# Altium schematic sheet Power.SchDoc(Power)
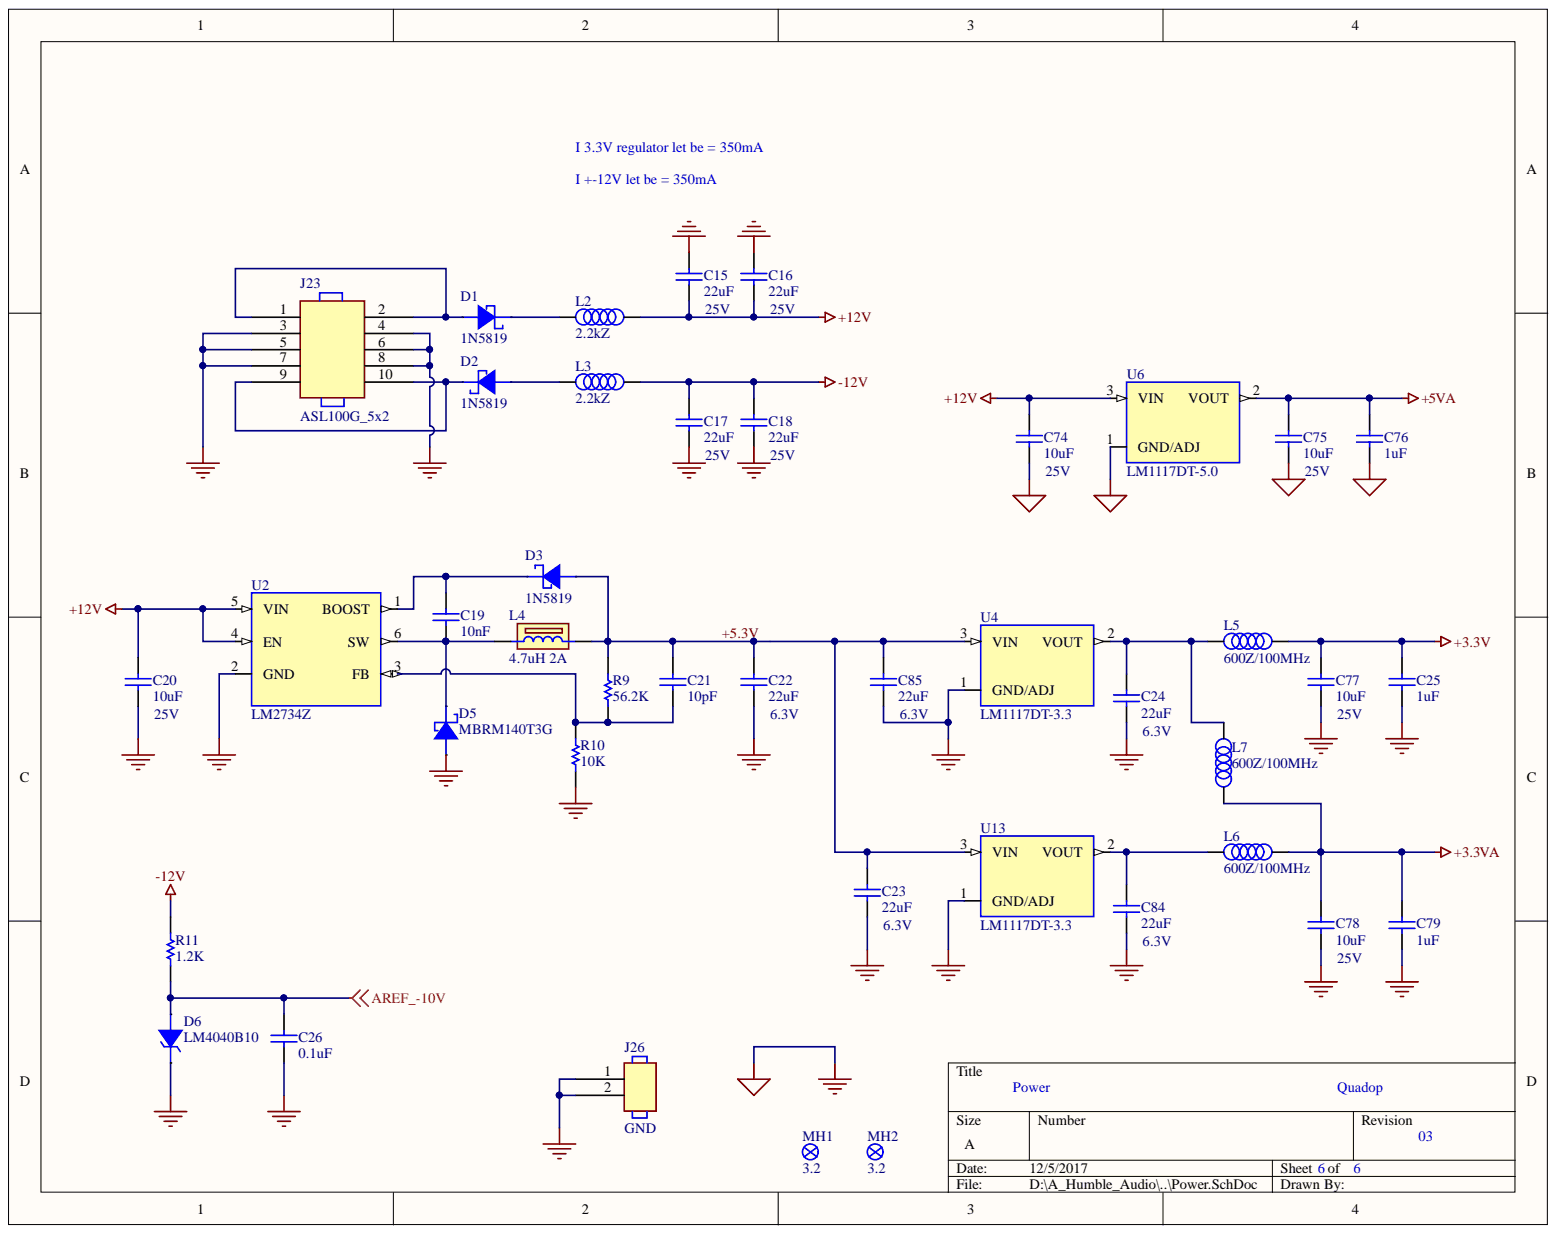
[diagram p0: Power.SchDoc]

## Parts
C15  22uF  Voltage: 25V  [C1210]
C16  22uF  Voltage: 25V  [C1210]
C17  22uF  Voltage: 25V  [C1210]
C18  22uF  Voltage: 25V  [C1210]
C19  10nF  [C0603]
C20  10uF  Voltage: 25V  [C0805]
C21  10pF  [C0603]
C22  22uF  Voltage: 6.3V  [C1206]
C23  22uF  Voltage: 6.3V  [C1206]
C24  22uF  Voltage: 6.3V  [C1206]
C25  1uF  [C0603]
C26  0.1uF  [C0603]
C74  10uF  Voltage: 25V  [C0805]
C75  10uF  Voltage: 25V  [C0805]
C76  1uF  [C0603]
C77  10uF  Voltage: 25V  [C0805]
C78  10uF  Voltage: 25V  [C0805]
C79  1uF  [C0603]
C84  22uF  Voltage: 6.3V  [C1206]
C85  22uF  Voltage: 6.3V  [C1206]
D1  1N5819  [SOD123]
D2  1N5819  [SOD123]
D3  1N5819  [SOD123]
D5  MBRM140T3G  [DO_216AA]
D6  LM4040B10  [SOT23]
J23  ASL100G_5x2  [SL2X5]
J26  GND  [SL1X2]
L2  2.2kZ  [M0805]
L3  2.2kZ  [M0805]
L4  4.7uH 2A  [L_IFSC_3.8x3.8x1.8]
L5  600Z/100MHz  [L1608]
L6  600Z/100MHz  [L1608]
L7  600Z/100MHz  [L1608]
MH1  3.2  [SK_32]
MH2  3.2  [SK_32]
R9  56.2K  [R0603]
R10  10K  [R0603]
R11  1.2K  [R0603]
U2  LM2734Z  [SOT23_6]
U4  LM1117DT-3.3  [TO252AA]
U6  LM1117DT-5.0  [TO252AA]
U13  LM1117DT-3.3  [TO252AA]

## Nets
+3.3V: C25.1 C77.1 L5.2
+3.3VA: C78.1 C79.1 L6.2 L7.1
+5VA: C75.1 C76.1 U6.2
+12V: C15.2 C16.2 C20.1 C74.1 L2.2 U2.4(EN) U2.5(VIN) U6.3
-12V: C17.1 C18.1 L3.2 R11.1
AGND: C15.1 C16.1 C17.2 C18.2 C20.2 C22.2 C23.2 C24.2 C25.2 C26.2 C74.2 C75.2 C76.2 C77.2 C78.2 C79.2 C84.2 C85.2 D5.1 D6.1 J23.3 J23.4 J23.5 J23.6 J23.7 J23.8 J26.1 J26.2 R10.2 U2.2(GND) U4.1 U6.1 U13.1
AREF_-10V: C26.1 D6.2 R11.2
+5.3V: C21.1 C22.1 C23.1 C85.1 D3.1 L4.2 R9.1 U4.3 U13.3
NetC19_1: C19.1 D3.2 U2.1(BOOST)
NetC19_2: C19.2 D5.2 L4.1 U2.6(SW)
NetC21_2: C21.2 R9.2 R10.1 U2.3(FB)
NetC24_1: C24.1 L5.1 L7.2 U4.2
NetC84_1: C84.1 L6.1 U13.2
NetD1_1: D1.1 J23.1 J23.2
NetD1_2: D1.2 L2.1
NetD2_1: D2.1 L3.1
NetD2_2: D2.2 J23.9 J23.10

## Library data of the parts
C15-C18 = 00226255: 22uF 25V 20% X5R tanδ=5 1210 -55+85; Manufacturer Part Number: TMK325BJ226MM-T; Manufacturer: Taiyo Yuden; Tolerance: +-20%; PSpice: C; Database Table Name: Capacitor; Value: 22uF; Tape Width: 8mm; SMD: Yes; Price: 0.86; Part Type: Ceramic; Part Number: 00226255; Package: 500T&R; Distributor Part Number: 587-2086-1-ND; Distributor: Digi-Key; Component Kind: Standard; Library Name: Gedo_DB.DbLib
C19 = 00000007: 10nF 50V 10% X7R 0603; Manufacturer Part Number: CC0603KRX7R9BB103; Manufacturer: Yageo; PSpice: C; Database Table Name: Capacitor; Voltage: 50V; Value: 10nF; Tolerance: 10%; SMD: Yes; Price: 0.015; Part Type: Ceramic; Part Number: 00000007; Distributor Part Number: 311-1085-1-ND; Distributor: Digi-Key; Component Kind: Standard; Availability: In Stock; Library Name: Gedo_DB.DbLib
C20 C74 C75 C77 C78 = 00106253: 10uF 25V 10% X5R 0805 -55+85; Manufacturer Part Number: TMK212BBJ106KG-T; Manufacturer: Taiyo Yuden; Tolerance: +-10%; PSpice: C; Database Table Name: Capacitor; Value: 10uF; Tape Width: 8mm; SMD: Yes; Price: 0.385; Part Type: Ceramic; Part Number: 00106253; Package: 3000T&R; Distributor Part Number: 587-2985-1-ND; Distributor: Digi-Key; Component Kind: Standard; Library Name: Gedo_DB.DbLib
C21 = 00000144: 10pF 50V 5% NP0 0603 -55+85; Tolerance: +-5%; PSpice: C; Database Table Name: Capacitor; Voltage: 50V; Value: 10pF; Tape Width: 8mm; SMD: Yes; Part Type: Ceramic; Part Number: 00000144; Component Kind: Standard; Availability: In Stock; Library Name: Gedo_DB.DbLib
C22-C24 C84 C85 = 00226634: 22uF 6.3V 20% X5R 1206 -55+85; Manufacturer Part Number: JMK316BJ226ML-T; Manufacturer: Taiyo Yuden; Tolerance: +-20%; PSpice: C; Database Table Name: Capacitor; Value: 22uF; Tape Width: 8mm; SMD: Yes; Price: 0.399; Part Type: Ceramic; Part Number: 00226634; Package: 2000T&R; Distributor Part Number: 587-1347-1-ND; Distributor: Digi-Key; Component Kind: Standard; Library Name: Gedo_DB.DbLib
C25 C76 C79 = 00000550: 1uF 16V 10% X5R 0603 -55+85; Manufacturer Part Number: GRM188R71C105KA12D; Manufacturer: MURATA; Tolerance: +-10%; PSpice: C; Database Table Name: Capacitor; Voltage: 16V; Value: 1uF; Tape Width: 8mm; SMD: Yes; Price: 0.13; Part Type: Ceramic; Part Number: 00000550; Package: 4000T&R; Distributor Part Number: 490-3900-1-ND; Distributor: Digi-Key; Component Kind: Standard; Availability: In Stock; Library Name: Gedo_DB.DbLib
C26 = 00000538: 100nF 50V +-10% X7R 0603 -55+125; Manufacturer Part Number: GRM188R71H104KA93J; Manufacturer: MURATA; PSpice: C; Lead time: Stock; Database Table Name: Capacitor; Voltage: 50V; Value: 0.1uF; Tolerance: +-10%; Tape Width: 8mm; SMD: Yes; Price: 0.0048; Part Type: Ceramic; Part Number: 00000538; Package: 10000T&R330mm; MOQ: 4000; Main distributor: Rutronik; Distributor: Rutronik; Component Kind: Standard; Availability: In Stock; ActivepartsID: http://search.murata.co.jp/Ceramy/GrsearchViewAction.do;jsessionid=C4D720578E4D2E51EC807AFF78C1B5C3; Library Name: Gedo_DB.DbLib
D1 D2 = 30000028: Diode Schotky Rectifier 1A 40V Vf=0.45V@1A,SOD-123,-65+125; Manufacturer Part Number: 1N5819HW-7-F; Manufacturer: Diodes Inc; PSpice: D; Database Table Name: Diodai; Value: 1N5819; SMD: Yes; Price: 0.371; Part Type: Schotky; Part Number: 30000028; Distributor Part Number: 1N5819HW-FDICT-ND; Distributor: Digi-Key; Component Kind: Standard; Library Name: Gedo_DB.DbLib
D3 = 30000028: Diode Schotky Rectifier 1A 40V Vf=0.45V@1A,SOD-123,-65+125; Manufacturer Part Number: 1N5819HW-7-F; Manufacturer: Diodes Inc; Database Table Name: Diodai; Value: 1N5819; SMD: Yes; Price: 0.371; Part Number: 30000028; Distributor Part Number: 1N5819HW-FDICT-ND; Distributor: Digi-Key; Component Kind: Standard; Library Name: Gedo_DB.DbLib
D5 = 30000026: Diode Schotky Rectifier 1A 40V Vf=0.55V@1A,DO-216AA,-55+125; Manufacturer: ON Semiconductor; Database Table Name: Diodai; Value: MBRM140T3G; SMD: Yes; Price: 0.371; Part Number: 30000026; Manufacturer Part Number: MBRM140T3G; Distributor Part Number: MBRM140T3GOSCT-ND; Distributor: Digi-Key; Component Kind: Standard; Library Name: Gedo_DB.DbLib
D6 = 31404010: Diode Zener 10Vnom,15mA SOT23 ,-40+85; Manufacturer Part Number: LM4040B10IDBZR; Manufacturer: Texas Instruments; Tolerance: +-0.2%; PSpice: D; Database Table Name: Diodai; Value: LM4040B10; Tape Width: 8mm; SMD: Yes; Price: 1.63; Part Type: Zener; Part Number: 31404010; Package: 3000T&R; Distributor Part Number: 296-20869-1-ND; Distributor: Digi-Key; Component Kind: Standard; Library Name: Gedo_DB.DbLib
J23 = 71001205: Header 5x2_2,54mm 250V,3A gold; Database Table Name: Connectors; Value: ASL100G_5x2; Rating: 250V; Price: 0.4555; Part Type: 2.54 header; Part Number: 71001205; Distributor Part Number: G5234 ASL100G; Distributor: SCHUKAT; Component Kind: Standard; Library Name: Gedo_DB.DbLib
J26 = 71001102: Header 2x2,54mm 250V,3A; Database Table Name: Connectors; Value: GND; Rating: 250V; Price: 0; Part Type: 2.54 header; Part Number: 71001102; Distributor Part Number: G5224 ASL050; Distributor: SCHUKAT; Component Kind: Standard; Library Name: Gedo_DB.DbLib
L2 L3 = 20000902: Chip Bead 2.2kΩs/100MHz 200mA R=600mΩ 0603 -55+125; Manufacturer Part Number: 742792093; Manufacturer: Wurth Electronics Inc; PSpice: L; Database Table Name: Inductors; Value: 2.2kZ; Tape Width: 8mm; SMD: Yes; Price: 0.218; Part Type: Ferrite beads; Part Number: 20000902; Package: T&R4000; Distributor Part Number: 732-1609-1-ND; Distributor: Digi-key; Component Kind: Standard; Library Name: Gedo_DB.DbLib
L4 = 21000121: Shielded SMD Power Inductors Idc=2A R=0.09Ohm; 3.8x3.8x1.8 -55+125; Manufacturer Part Number: IFSC1515AHER4R7M01; Manufacturer: Vishay Dale; PSpice: L; Value: 4.7uH 2A; SMD: Yes; Price: 0.41; Part Type: Power Inductor Sh; Part Number: 21000121; Distributor Part Number: 541-1405-1-ND; Distributor: Digi-key; Database Table Name: Inductors; Component Kind: Standard; Library Name: Gedo_DB.DbLib
L5-L7 = 20000006: Chip Bead 600Ωs/100MHz 0.5A Rdc=0.38Ω; 0603; Manufacturer Part Number: BLM18AG601SN1D; Manufacturer: Murata; PSpice: L; Database Table Name: Inductors; Value: 600Z/100MHz; SMD: Yes; Price: 0.07; Part Type: Ferrite beads; Part Number: 20000006; Distributor Part Number: 1515679; Distributor: Farnell; Component Kind: Standard; Library Name: Gedo_DB.DbLib
MH1 MH2 = 91000103: PCB Mouting hole 3.2  neperkamas; Database Table Name: Misc; Value: 3.2; Part Type: Mechanic\PCB Mouting hole; Part Number: 91000103; MOQ: 0; Component Kind: Standard; Library Name: Gedo_DB.DbLib
R9 = 11056222: 56.2K 1% 0603 1/10W 50V 100ppm; Manufacturer Part Number: RC0603FR-0756K2L; Manufacturer: YAGEO; PSpice: R; Database Table Name: Resistor; Value: 56.2K; Tolerance: 1%; Tape Width: 8mm; SMD: Yes; Power: 1/10W; Part type: SMD 1%; Part Number: 11056222; Package: 5000T&R; Distributor Part Number: 2059477; Distributor: Farnell; Component Kind: Standard; Availability: In Stock; ActivepartsID: http://www.yageo.com/pdf/Pu-RC0603_51_PbFree_L_2.pdf; Library Name: Gedo_DB.DbLib
R10 = 11010022: 10K 1% 0603 1/10W 100ppm; Manufacturer Part Number: RC0603FR-0710KL; Manufacturer: YAGEO; PSpice: R; Database Table Name: Resistor; Value: 10K; Tolerance: 1%; Tape Width: 8mm; SMD: Yes; Price: 0.0057; Power: 1/10W; Part type: SMD 1%; Part Number: 11010022; Package: 5000T&R; Distributor Part Number: 311-10.0KHRCT-ND; Distributor: Digi-Key; Component Kind: Standard; Availability: In Stock; Library Name: Gedo_DB.DbLib
R11 = 10001222: 1K2 5% 0603 1/10W 200ppm; Manufacturer Part Number: RC0603JR-071K2L; Manufacturer: YAGEO; PSpice: R; Database Table Name: Resistor; Value: 1.2K; Tolerance: 5%; Tape Width: 8mm; SMD: Yes; Power: 1/10W; Part type: SMD 5%; Part Number: 10001222; Package: 5000T&R; Component Kind: Standard; Availability: In Stock; Library Name: Gedo_DB.DbLib
U2 = 50800417: Buck DC-DC controller Vin=3V-20V,1A,3MHz,Vfb=0.8V,SOT-23-6,-40+125; Manufacturer Part Number: LM2734ZQMKE; Manufacturer: TEXAS INSTRUMENTS; Database Table Name: IC; Value: LM2734Z; SMD: Yes; Price: 9.12; Part Type: DC-DC\BUCK; Part Number: 50800417; Distributor Part Number: 1663026; Distributor: Farnell; Component Kind: Standard; Library Name: Gedo_DB.DbLib
U4 U13 = 50801170: 3.3V positive reg 15Vin max 800mA Vdrop=1.2V; SOT-252-3; -40+125; Manufacturer Part Number: LM1117IDTX-3.3/NOPB; Manufacturer: Texas Instruments Semiconductors; Database Table Name: IC; Value: LM1117DT-3.3; Tape Width: 12mm; SMD: Yes; Price: 1.19; Part Type: DC-DC\LDO; Part Number: 50801170; Package: 2500T&R; Distributor Part Number: LM1117IDTX-3.3/NOPBCT-ND; Distributor: Digi-Key; Component Kind: Standard; Library Name: Gedo_DB.DbLib
U6 = 50801175: 5.0V positive reg 15Vin max 800mA Vdrop=1.2V; SOT-252-3; -40+125; Manufacturer Part Number: LM1117IDTX-5.0/NOPB; Manufacturer: Texas Instruments Semiconductors; Database Table Name: IC; Value: LM1117DT-5.0; Tape Width: 12mm; SMD: Yes; Price: 1.19; Part Type: DC-DC\LDO; Part Number: 50801175; Package: 2500T&R; Distributor Part Number: LM1117IDTX-5.0/NOPBCT-ND; Distributor: Digi-Key; Component Kind: Standard; Library Name: Gedo_DB.DbLib
